# Altium schematic sheet picoamp_v30_PSU.SchDoc
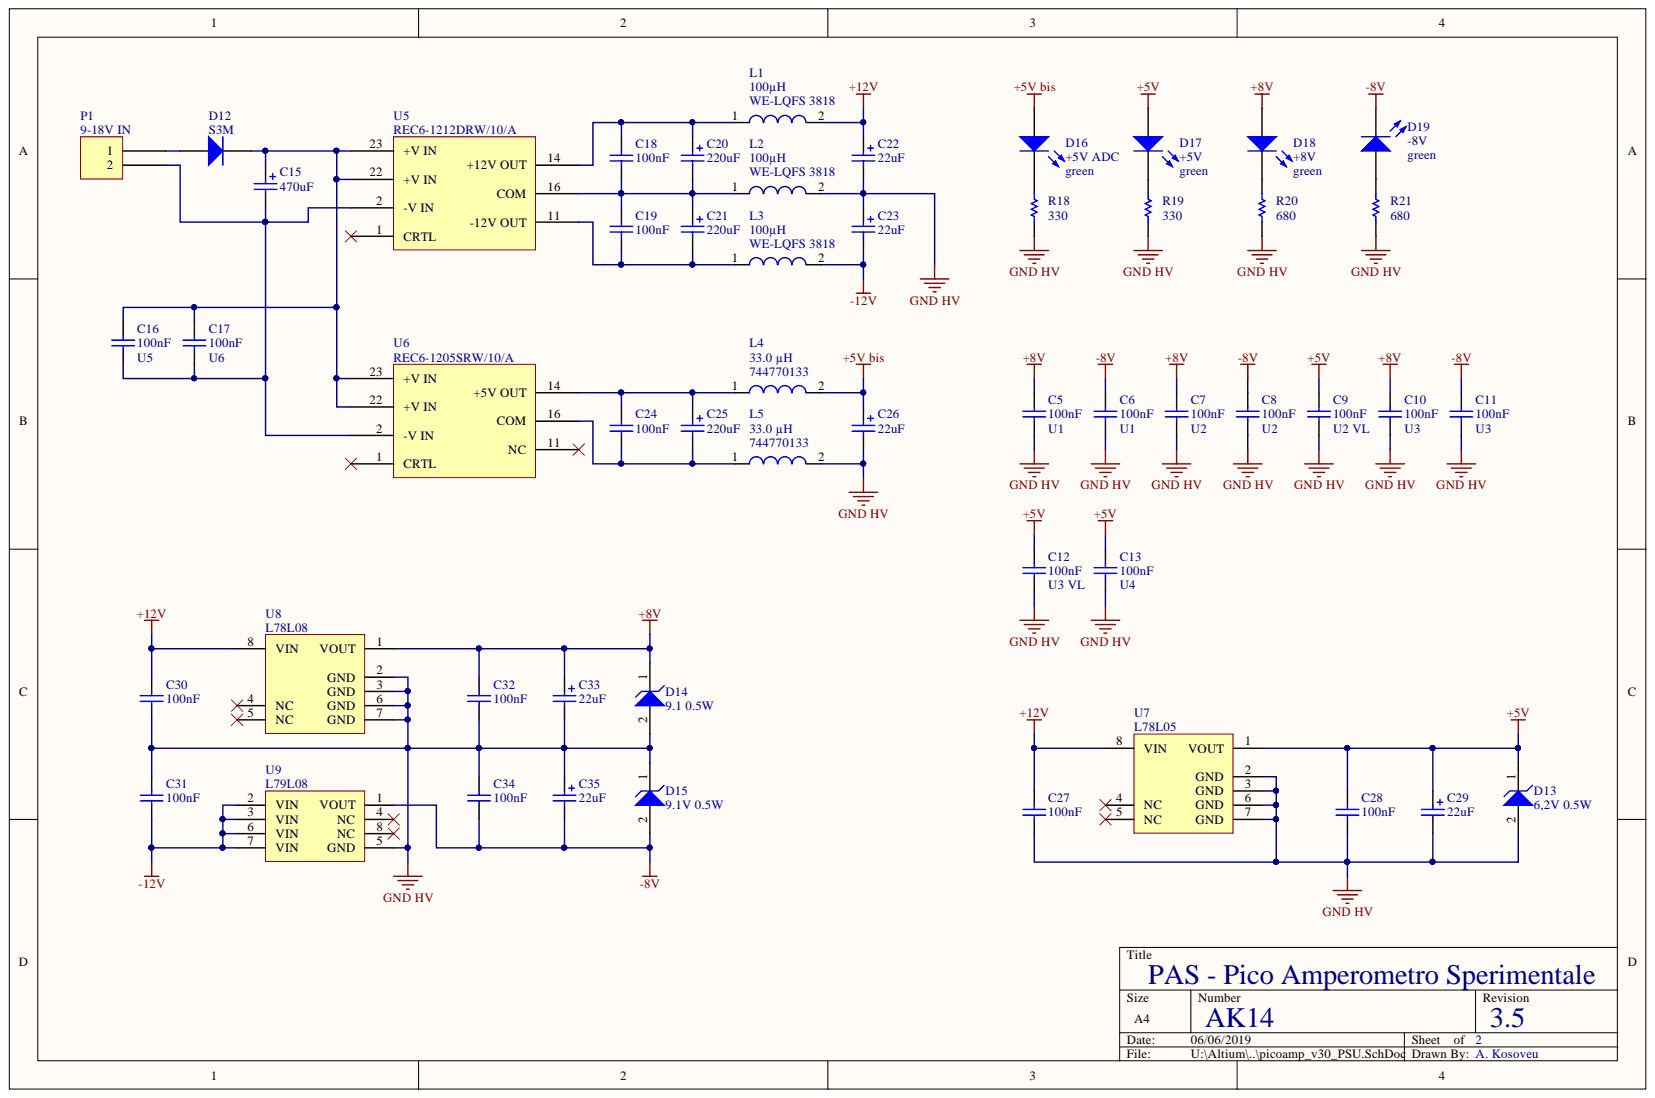
[diagram p0: picoamp_v30_PSU.SchDoc]

## Parts
C5  U1  value 100nF  [C 1206 (3216)]
C6  U1  value 100nF  [C 1206 (3216)]
C7  U2  value 100nF  [C 1206 (3216)]
C8  U2  value 100nF  [C 1206 (3216)]
C9  U2 VL  value 100nF  [C 1206 (3216)]
C10  U3  value 100nF  [C 1206 (3216)]
C11  U3  value 100nF  [C 1206 (3216)]
C12  U3 VL  value 100nF  [C 1206 (3216)]
C13  U4  value 100nF  [C 1206 (3216)]
C15  value 470uF  [C EL SMD 10MM]  (hidden comment: Cap Pol)
C16  U5  value 100nF  [C 1206 (3216)]
C17  U6  value 100nF  [C 1206 (3216)]
C18  value 100nF  [C 1206 (3216)]
C19  value 100nF  [C 1206 (3216)]
C20  value 220uF  [C EL SMD 10MM]  (hidden comment: Cap Pol)
C21  value 220uF  [C EL SMD 10MM]  (hidden comment: Cap Pol)
C22  value 22uF  [C]  (hidden comment: T491C)
C23  value 22uF  [C]  (hidden comment: T491C)
C24  value 100nF  [C 1206 (3216)]
C25  value 220uF  [C EL SMD 10MM]  (hidden comment: Cap Pol)
C26  value 22uF  [C]  (hidden comment: T491C)
C27  value 100nF  [C 1206 (3216)]  (hidden comment: Cap)
C28  value 100nF  [C 1206 (3216)]  (hidden comment: Cap)
C29  value 22uF  [C]  (hidden comment: T491C)
C30  value 100nF  [C 1206 (3216)]  (hidden comment: Cap)
C31  value 100nF  [C 1206 (3216)]  (hidden comment: Cap)
C32  value 100nF  [C 1206 (3216)]  (hidden comment: Cap)
C33  value 22uF  [C]  (hidden comment: T491C)
C34  value 100nF  [C 1206 (3216)]  (hidden comment: Cap)
C35  value 22uF  [C]  (hidden comment: T491C)
D12  S3M  [SMC]
D13  6,2V 0.5W  [ONSC-SOD-123-2-425-04_V]
D14  9.1 0.5W  [ONSC-SOD-123-2-425-04_V]
D15  9.1V 0.5W  [ONSC-SOD-123-2-425-04_V]
D16  +5V ADC  colore: green  [3.2X1.6X1.1]
D17  +5V  colore: green  [3.2X1.6X1.1]
D18  +8V  colore: green  [3.2X1.6X1.1]
D19  -8V  colore: green  [3.2X1.6X1.1]
L1  WE-LQFS 3818  Inductance: 100µH  PackageReference: WE-LQFS  [WE-LQFS 3818]
L2  WE-LQFS 3818  Inductance: 100µH  PackageReference: WE-LQFS  [WE-LQFS 3818]
L3  WE-LQFS 3818  Inductance: 100µH  PackageReference: WE-LQFS  [WE-LQFS 3818]
L4  744770133  Inductance: 33.0 µH  [WE-PD]
L5  744770133  Inductance: 33.0 µH  [WE-PD]
P1  9-18V IN  [conn. vite 2 pos.]
R18  value 330  [R 1206 [3216]]  (hidden comment: Res)
R19  value 330  [R 1206 [3216]]  (hidden comment: Res)
R20  value 680  [R 1206 [3216]]  (hidden comment: Res)
R21  value 680  [R 1206 [3216]]  (hidden comment: Res)
U5  REC6-1212DRW/10/A  [REC6/R]
U6  REC6-1205SRW/10/A  [REC6/R]
U7  L78L05  [SO8_N]
U8  L78L08  [SO8_N]
U9  L79L08  [SO8_N]

## Nets
+5V: C9.2 C12.2 C13.2 C28.2 C29.1 D13.1 D17.1 U7.1(VOUT)
+5V BIS: C26.1 D16.1 L4.2
+8V: C5.2 C7.2 C10.2 C32.2 C33.1 D14.1 D18.1 U8.1(VOUT)
+12V: C22.1 C27.2 C30.2 L1.2 U7.8(VIN) U8.8(VIN)
-8V: C6.2 C8.2 C11.2 C34.1 C35.2 D15.2 D19.2 U9.1(VOUT)
-12V: C23.2 C31.1 L3.2 U9.2(VIN) U9.3(VIN) U9.6(VIN) U9.7(VIN)
GND HV: C5.1 C6.1 C7.1 C8.1 C9.1 C10.1 C11.1 C12.1 C13.1 C22.2 C23.1 C26.2 C27.1 C28.1 C29.2 C30.1 C31.2 C32.1 C33.2 C34.2 C35.1 D13.2 D14.2 D15.1 L2.2 L5.2 R18.1 R19.1 R20.1 R21.1 U7.2(GND) U7.3(GND) U7.6(GND) U7.7(GND) U8.2(GND) U8.3(GND) U8.6(GND) U8.7(GND) U9.5(GND)
NetC15_1: C15.1 C16.2 C17.2 D12.2 U5.22(+V_IN) U5.23(+V_IN) U6.22(+V_IN) U6.23(+V_IN)
NetC15_2: C15.2 C16.1 C17.1 P1.2 U5.2(-V_IN) U6.2(-V_IN)
NetC18_1: C18.1 C19.2 C20.2 C21.1 L2.1 U5.16(COM)
NetC18_2: C18.2 C20.1 L1.1 U5.14(+12V_OUT)
NetC19_1: C19.1 C21.2 L3.1 U5.11(-12V_OUT)
NetC24_1: C24.1 C25.2 L5.1 U6.16(COM)
NetC24_2: C24.2 C25.1 L4.1 U6.14(+5V_OUT)
NetD12_1: D12.1 P1.1
NetD16_2: D16.2 R18.2
NetD17_2: D17.2 R19.2
NetD18_2: D18.2 R20.2
NetD19_1: D19.1 R21.2

Not connected: U5 1(CRTL); U6 1(CRTL) 11(NC); U7 4(NC) 5(NC); U8 4(NC) 5(NC); U9 4(NC) 8(NC)

## Library data of the parts
C5-C13 C16-C19 C24 C27 C28 C30-C32 C34 = Cap: Capacitor; Component Kind: Standard; LatestRevisionDate: 17-Jul-2002; LatestRevisionNote: Re-released for DXP Platform.; PackageDescription: Radial Cap, Thru-Hole; 2 Leads; 0.3 in Pin Spacing; PackageReference: RAD-0.3; Published: 8-Jun-2000; Signal Integrity: Cap; Simulation: CAP; Library Name: picoamp_v30.SchLib; Publisher: Altium Limited
C15 C20 C21 C25 = Cap Pol: Polarized Capacitor (Surface Mount); Component Kind: Standard; LatestRevisionDate: 29-May-2009; LatestRevisionNote: IPC-7351 Footprint Added.; PackageDescription: Chip Capacitor; PackageReference: C0805; Published: 8-Jun-2000; Signal Integrity: Cap Pol; Simulation: CAP; Library Name: picoamp_v30.SchLib; Publisher: Altium Limited
C22 C23 C26 C29 C33 C35 = T491C: Solid Tantalum Chip Capacitor, Standard T491 Series - Industrial Grade; Code_JEITA: 6032-28; Component Kind: Standard; ComponentLink1Description: Manufacturer Link; ComponentLink1URL: http://www.kemet.com/; ComponentLink2Description: Datasheet; ComponentLink2URL: http://www.kemet.com/kemet/web/homepage/kechome.nsf/vapubfilesname/F3102TA.pdf/$file/F3102TA.pdf; DatasheetDocument: Mar-2001; LatestRevisionDate: 19-Mar-2004; LatestRevisionNote: Silkscreen dimensions re-sized.; PackageDocument: Mar-2001; PackageReference: C; Published: 17-Jul-2002; Signal Integrity: T491C; Simulation: CAP; Library Name: picoamp_v30.SchLib; Publisher: Altium Limited
D12 = Diode: Default Diode; Code_JEDEC: DO-214-AB; Component Kind: Standard; LatestRevisionDate: 17-Jul-2002; LatestRevisionNote: Re-released for DXP Platform.; PackageDescription: DO-214-AB/SMC; 2 C-Bend Leads; Body 7.9 x 5.9 mm, inc. leads (LxW); PackageReference: SMC; PackageVersion: Sep-1996; Published: 8-Jun-2000; Signal Integrity: Diode; Simulation: DIODE; Library Name: picoamp_v30.SchLib; Publisher: Altium Limited
D13-D15 = MMSZ5252ET1G: Zener Voltage Regulator, 500 mW, 2-Pin SOD-123, Pb-Free, Tape and Reel; Manufacturer: On Semiconductor; P Max (W): 0.5; VZ Typ (V): 24; Component Kind: Standard; ComponentLink1Description: Manufacturer URL; ComponentLink1URL: http://www.onsemi.com/; ComponentLink2Description: Datasheet; ComponentLink2URL: http://www.onsemi.com/pub_link/Collateral/MMSZ5221ET1-D.PDF; ComponentLink3Description: Package Specification; ComponentLink3URL: http://www.onsemi.com/pub_link/Collateral/425-04.PDF; DatasheetVersion: Rev. 8; Mounting Technology: Surface Mount; PackageDescription: 2-Pin SOD, Body 1.6 x 2.69 mm; PackageReference: SOD-123-2-425-04; PackageVersion: Rev. G, 10/2009; Packing: Tape and Reel; PartNumber: MMSZ5252ET1G; RoHS: Pb-Free; Supplier 1: Digi-Key; Supplier 2: Digi-Key; Supplier 3: Newark; Supplier 4: Mouser; Supplier Part Number 1: MMSZ5252ET1GOSTR-ND; Supplier Part Number 2: MMSZ5252ET1GOSCT-ND; Supplier Part Number 3: 26K4598; Supplier Part Number 4: 863-MMSZ5252ET1G; Library Name: picoamp_v30.SchLib
D16-D19 = LED2: Typical RED, GREEN, YELLOW, AMBER GaAs LED; Component Kind: Standard; LatestRevisionDate: 17-Jul-2002; LatestRevisionNote: Re-released for DXP Platform.; PackageDescription: SM LED; 2 Flat Leads; PackageReference: 3.2X1.6X1.1; PackageVersion: Feb-2002; Published: 8-Jun-2000; Simulation: LED2; Library Name: picoamp_v30.SchLib; Publisher: Altium Limited
L1-L3 = WE-LQFS 3818: WE-LQFS SMD Power Inductor; Component Kind: Standard; ComponentLink1Description: Manufacturer URL; ComponentLink1URL: http://www.we-online.com; ComponentLink2Description: Datasheet; ComponentLink2URL: http://katalog.we-online.de/pbs/datasheet/74404024010.pdf; PartNumber: WE-LQFS 3818; Library Name: picoamp_v30.SchLib
L4 L5 = 744770133: SMD-Shielded Power Inductor WE-PD, L = 33.0 µH; Component Kind: Standard; ComponentLink1Description: Manufacturer URL; ComponentLink1URL: http://www.we-online.com; ComponentLink2Description: Datasheet; ComponentLink2URL: http://www.we-online.de/katalog/media/pdf/744770133.pdf; DatasheetVersion: ver 5, Oct-2004; PackageDescription: SMD Inductor, 2-Leads, Body 12.0 x 12.0 mm; PackageReference: WE-PD-XL; PartNumber: 744770133; Library Name: picoamp_v30.SchLib
P1 = Conn. a vite 2 pos.: Component Kind: Standard; Library Name: picoamp_v30.SchLib
R18-R21 = Res: Resistor; Component Kind: Standard; LatestRevisionDate: 17-Jul-2002; LatestRevisionNote: Re-released for DXP Platform.; PackageDescription: Axial Device, Thru-Hole; 2 Leads; 0.5 in Pin Spacing; PackageReference: AXIAL-0.5; Published: 8-Jun-2000; Signal Integrity: Res Semi; Simulation: RES; Library Name: picoamp_v30.SchLib; Publisher: Altium Limited
U5 = REC6-1212DRW/10/A: 6E DIP24 REGULATED CONVERTER; Component Kind: Standard; Library Name: picoamp_v30.SchLib
U6 = REC6-1205SRW/10/A: 6E DIP24 REGULATED CONVERTER; Component Kind: Standard; Library Name: picoamp_v30.SchLib
U7 = L78L05: Positive Voltage Regulator, 5V, 8-Pin SO; PartNumber: L78L05CD13TR; Code_IPC: SOIC127P600-8; Code_JEDEC: MS-012-AA; Component Kind: Standard; ComponentLink1Description: Manufacturer URL; ComponentLink1URL: http://www.st.com; ComponentLink2Description: Datasheet; ComponentLink2URL: http://www.st.com/internet/com/TECHNICAL_RESOURCES/TECHNICAL_LITERATURE/DATASHEET/CD00000446.pdf; ComponentLink3Description: Package Specification; ComponentLink3URL: http://www.st.com/internet/com/TECHNICAL_RESOURCES/TECHNICAL_LITERATURE/PACKAGE_INFORMATION/CD00004680.pdf; DatasheetVersion: Feb-2011; PackageDescription: 8-Pin Small Outline Integrated Circuit 1.27 mm Pitch; PackageReference: SO8; PackageVersion: Dec-2002; Library Name: picoamp_v30.SchLib
U8 = L78L08: Positive Voltage Regulator, 8V, 8-Pin SO; PartNumber: L78L08CD13TR; Code_IPC: SOIC127P600-8; Code_JEDEC: MS-012-AA; Component Kind: Standard; ComponentLink1Description: Manufacturer URL; ComponentLink1URL: http://www.st.com; ComponentLink2Description: Datasheet; ComponentLink2URL: http://www.st.com/internet/com/TECHNICAL_RESOURCES/TECHNICAL_LITERATURE/DATASHEET/CD00000446.pdf; ComponentLink3Description: Package Specification; ComponentLink3URL: http://www.st.com/internet/com/TECHNICAL_RESOURCES/TECHNICAL_LITERATURE/PACKAGE_INFORMATION/CD00004680.pdf; DatasheetVersion: Feb-2011; PackageDescription: 8-Pin Small Outline Integrated Circuit 1.27 mm Pitch; PackageReference: SO8; PackageVersion: Dec-2002; Library Name: picoamp_v30.SchLib
U9 = L79L08: Negative Voltage Regulator, -8V, 8-Pin SO; PartNumber: L79L08ACD13TR; Code_IPC: SOIC127P600-8; Code_JEDEC: MS-012-AA; Component Kind: Standard; ComponentLink1Description: Manufacturer URL; ComponentLink1URL: http://www.st.com; ComponentLink2Description: Datasheet; ComponentLink2URL: http://www.st.com/internet/com/TECHNICAL_RESOURCES/TECHNICAL_LITERATURE/DATASHEET/CD00000539.pdf; ComponentLink3Description: Package Specification; ComponentLink3URL: http://www.st.com/internet/com/TECHNICAL_RESOURCES/TECHNICAL_LITERATURE/PACKAGE_INFORMATION/CD00004680.pdf; DatasheetVersion: Jul-2009; PackageDescription: 8-Pin Small Outline Integrated Circuit 1.27 mm Pitch; PackageReference: SO8; PackageVersion: Dec-2002; Library Name: picoamp_v30.SchLib
